# Altium schematic sheet P04_STM32MP1_PART_A_eMMC_.SchDoc(P04_STM32MP1_PART_A_eMMC_)
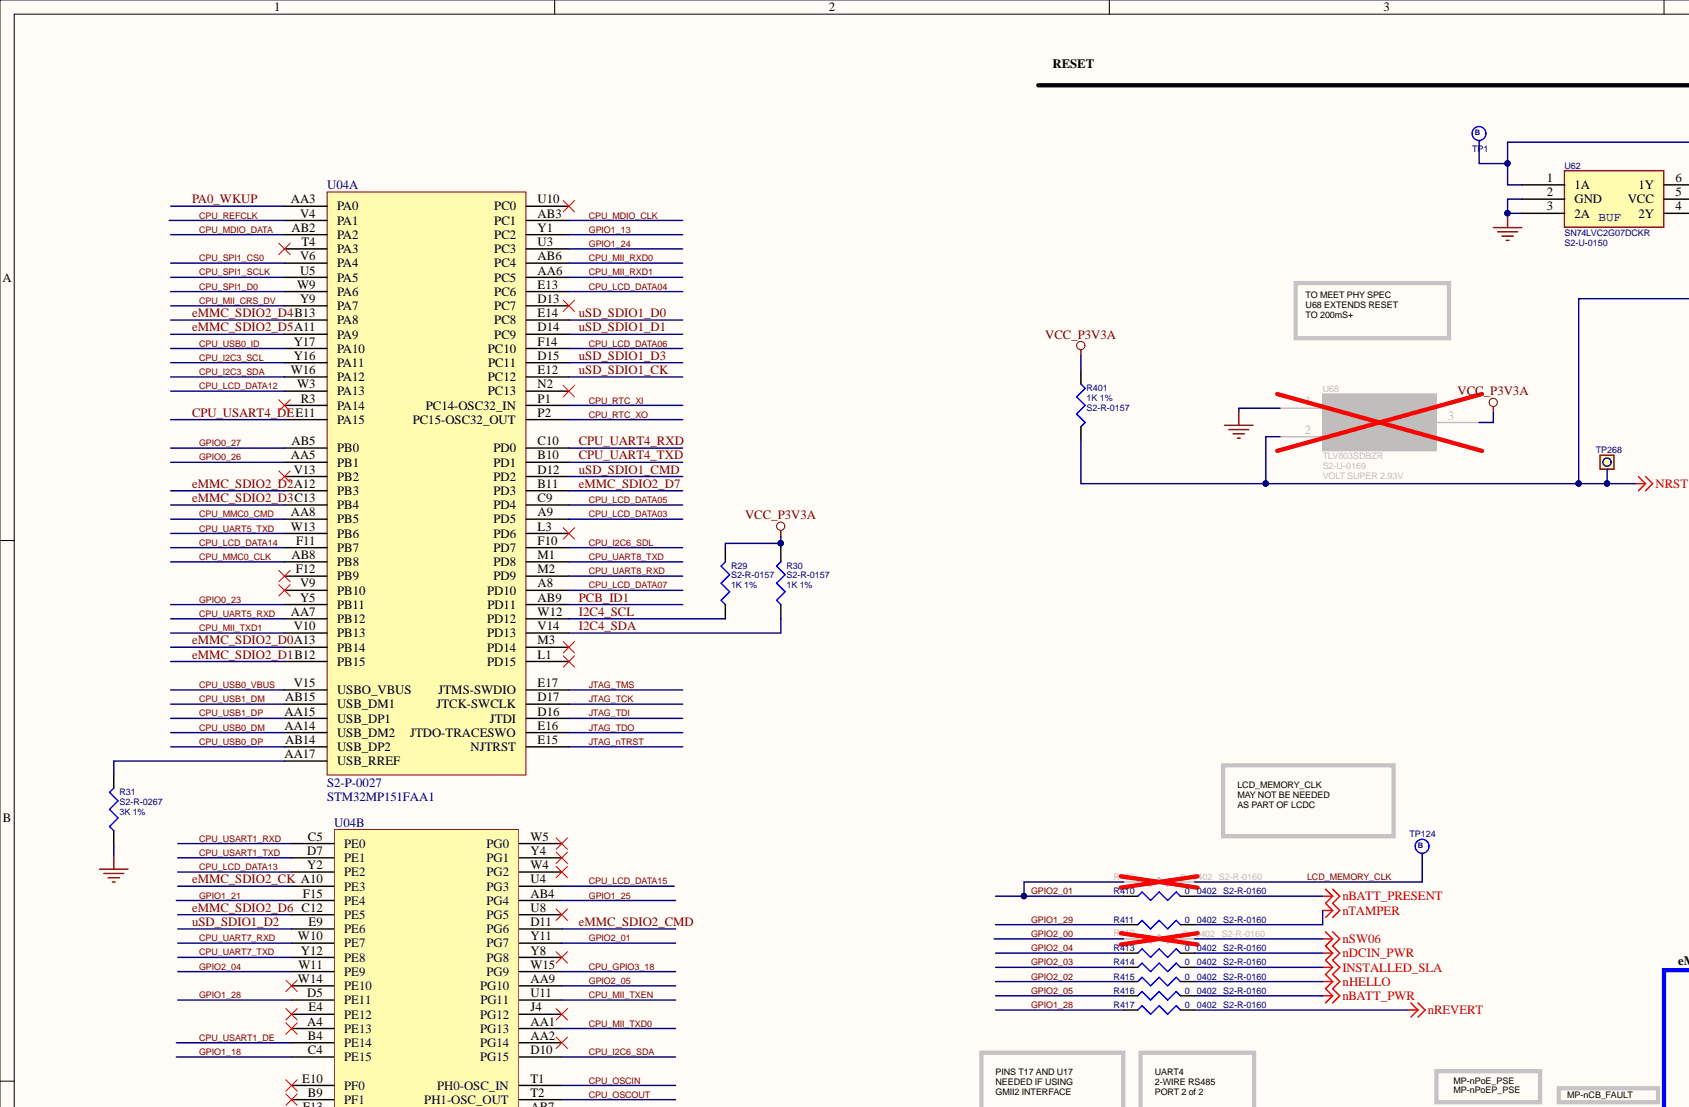
[diagram p0: P04_STM32MP1_PART_A_eMMC_.SchDoc, top left]
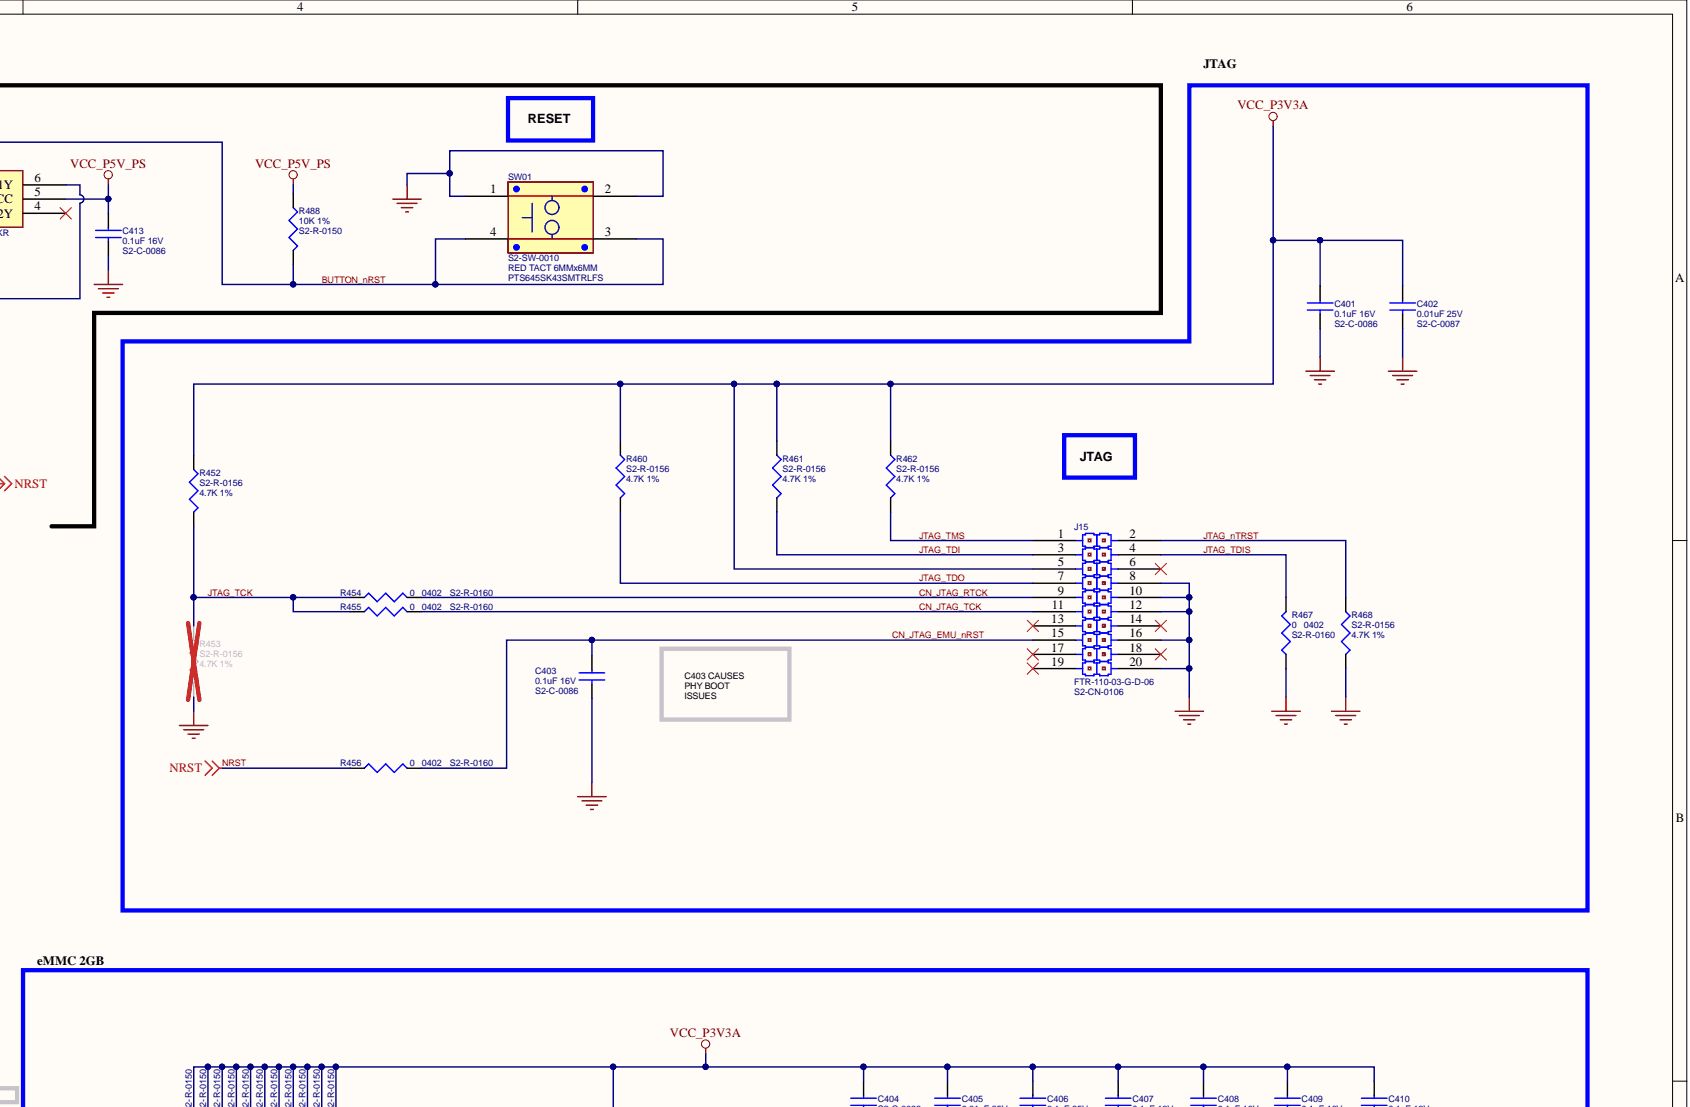
[diagram p1: P04_STM32MP1_PART_A_eMMC_.SchDoc, top right]
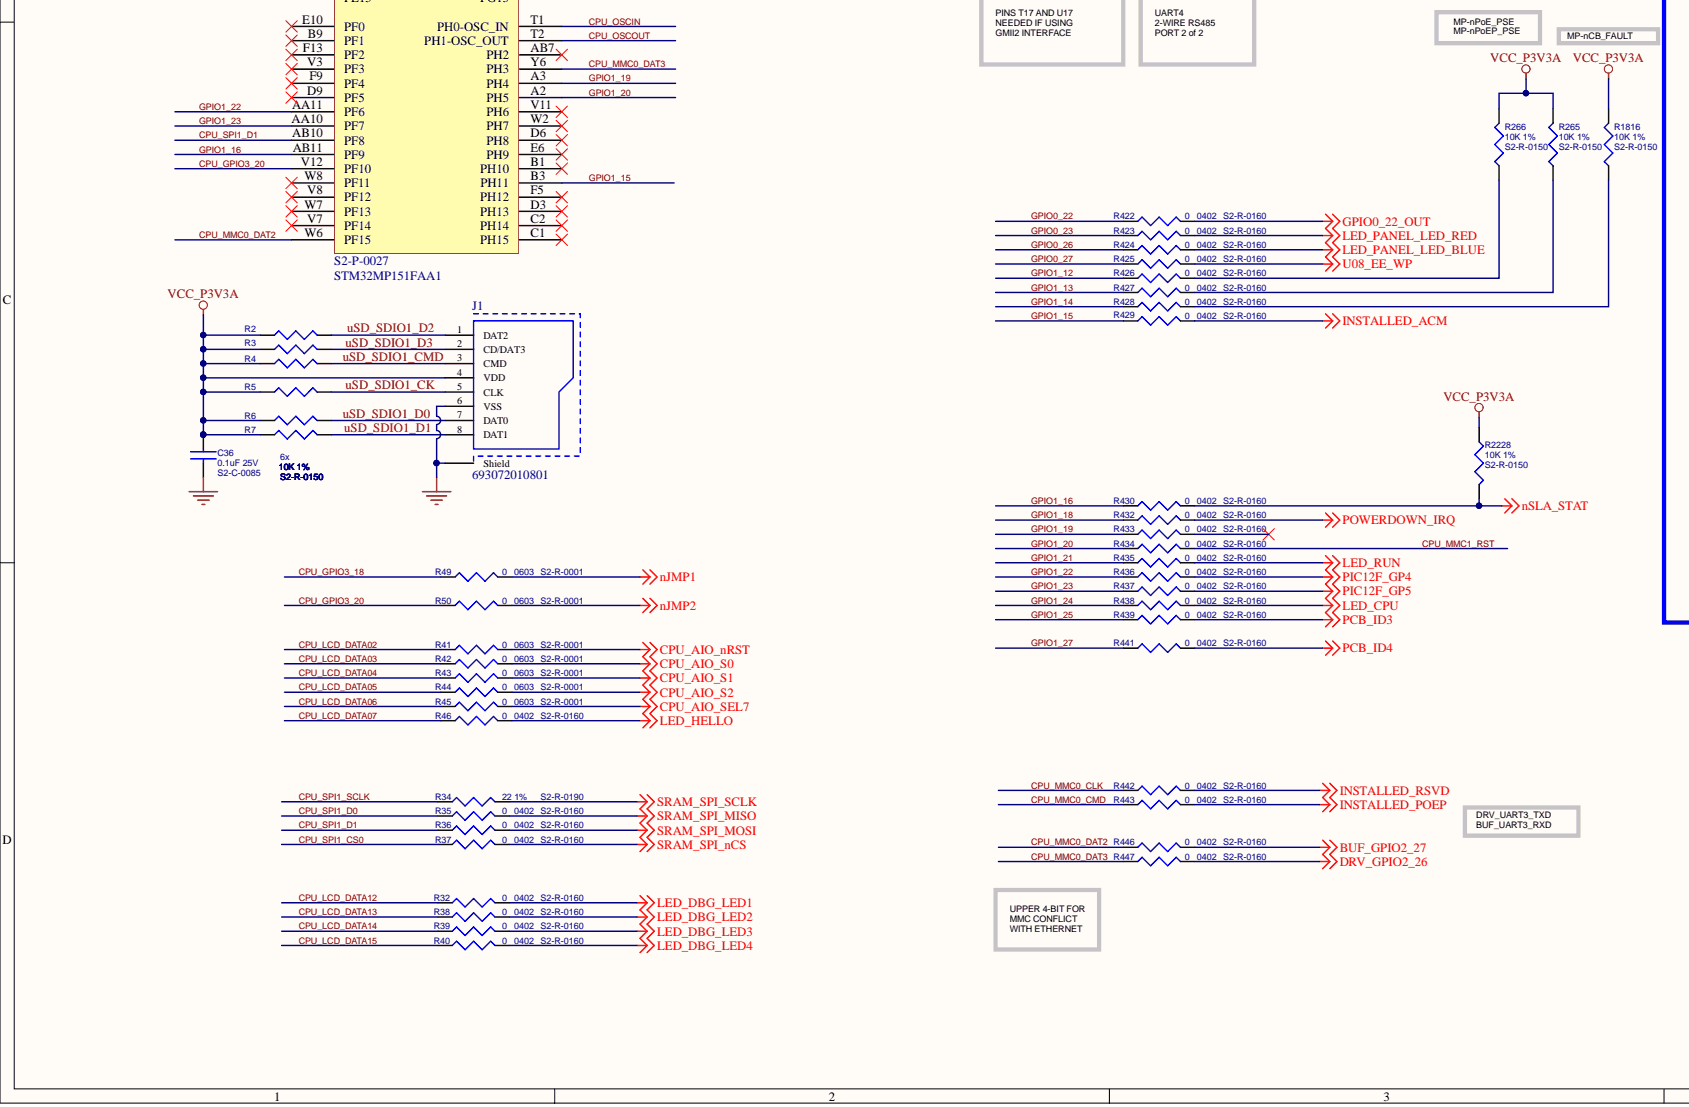
[diagram p2: P04_STM32MP1_PART_A_eMMC_.SchDoc, bottom left]
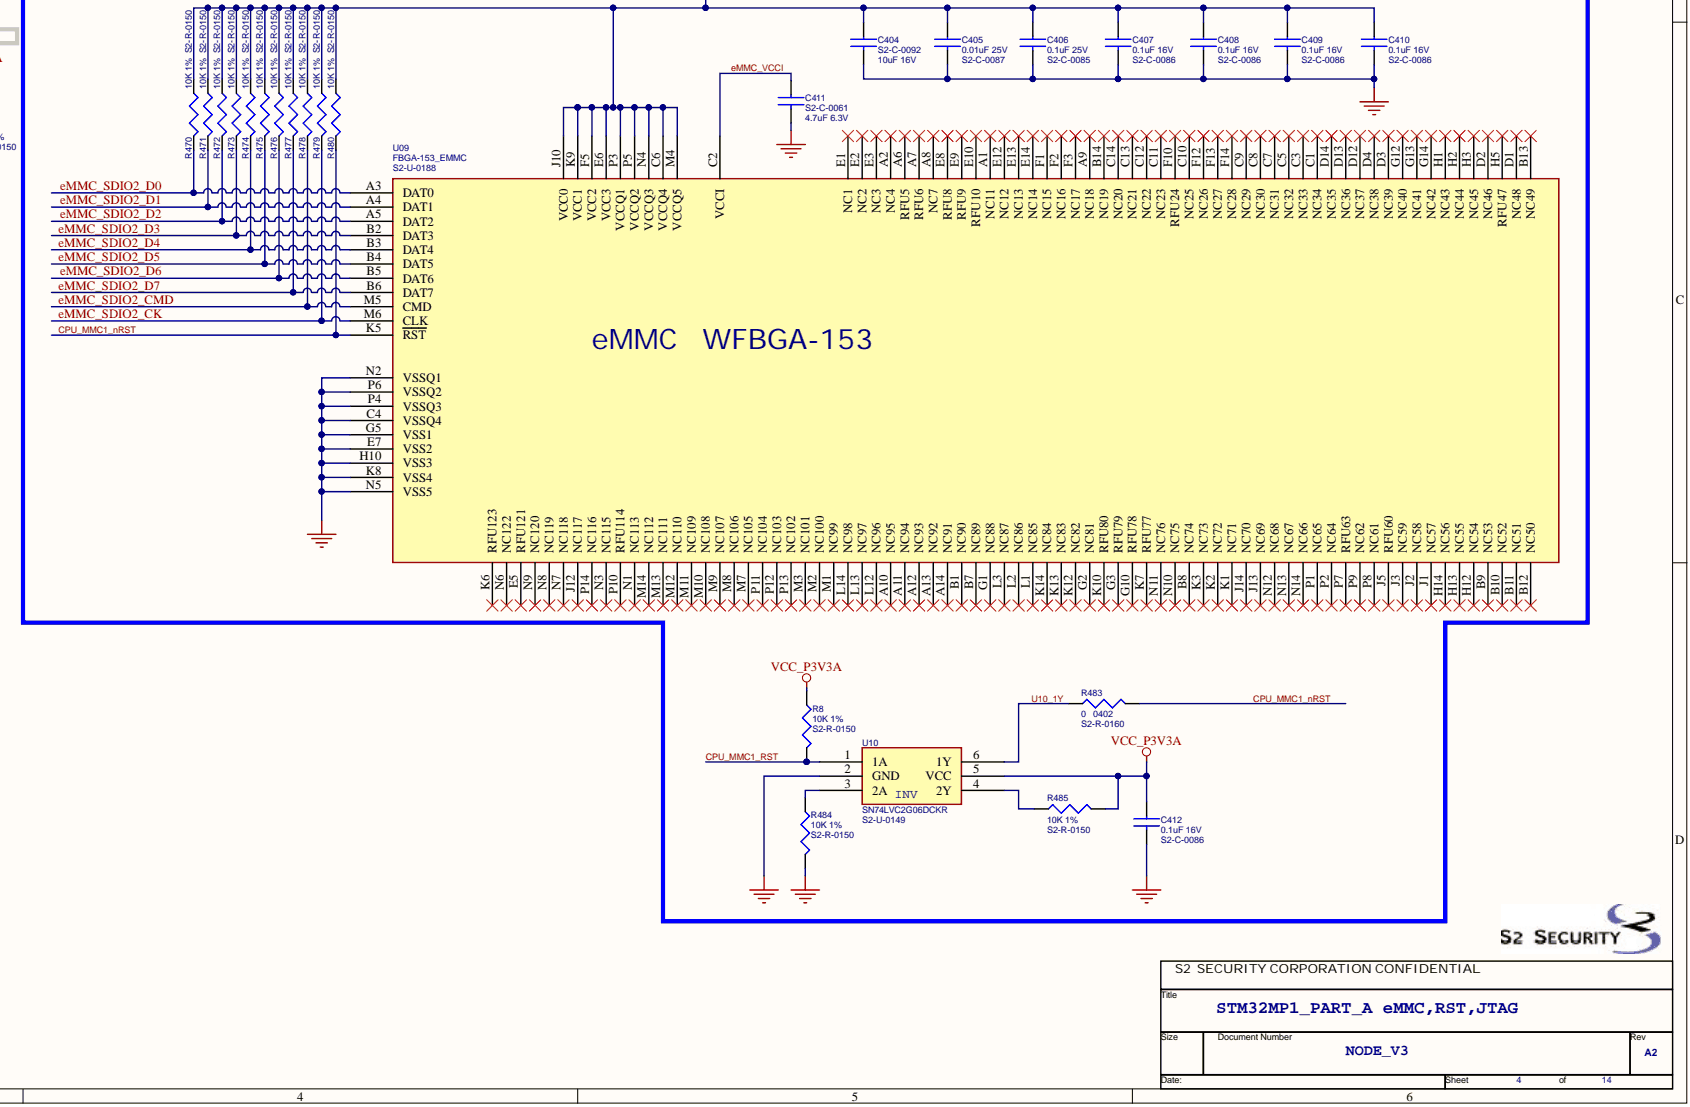
[diagram p3: P04_STM32MP1_PART_A_eMMC_.SchDoc, bottom right]

## Parts
C36  S2_PN: S2-C-0085  DESC: 0.1uF 25V  [CAPC1608X90N]  (hidden comment: C_0U1_25V_0603)
C401  S2_PN: S2-C-0086  DESC: 0.1uF 16V  [CAPC1005X55N]  (hidden comment: C_0U1_16V_0402)
C402  S2_PN: S2-C-0087  DESC: 0.01uF 25V  [CAPC1005X55N]  (hidden comment: C_0U01_25V_0402)
C403  S2_PN: S2-C-0086  DESC: 0.1uF 16V  [CAPC1005X55N]  (hidden comment: C_0U1_16V_0402)
C404  S2_PN: S2-C-0092  DESC: 10uF 16V  [CAPC2012X145N]  (hidden comment: C_10U_16V_0805)
C405  S2_PN: S2-C-0087  DESC: 0.01uF 25V  [CAPC1005X55N]  (hidden comment: C_0U01_25V_0402)
C406  S2_PN: S2-C-0085  DESC: 0.1uF 25V  [CAPC1608X90N]  (hidden comment: C_0U1_25V_0603)
C407  S2_PN: S2-C-0086  DESC: 0.1uF 16V  [CAPC1005X55N]  (hidden comment: C_0U1_16V_0402)
C408  S2_PN: S2-C-0086  DESC: 0.1uF 16V  [CAPC1005X55N]  (hidden comment: C_0U1_16V_0402)
C409  S2_PN: S2-C-0086  DESC: 0.1uF 16V  [CAPC1005X55N]  (hidden comment: C_0U1_16V_0402)
C410  S2_PN: S2-C-0086  DESC: 0.1uF 16V  [CAPC1005X55N]  (hidden comment: C_0U1_16V_0402)
C411  S2_PN: S2-C-0061  [CAPC1608X90N]  (hidden comment: C_4U7_6V3_0603)
C412  S2_PN: S2-C-0086  DESC: 0.1uF 16V  [CAPC1005X55N]  (hidden comment: C_0U1_16V_0402)
C413  S2_PN: S2-C-0086  DESC: 0.1uF 16V  [CAPC1005X55N]  (hidden comment: C_0U1_16V_0402)
J1  693072010801  [693072010801]
J15  S2_PN: S2-CN-0106  DESC: FTR-110-03-G-D-06  [CONN_FTR-110-03-G-D-06]  (hidden comment: CN_1MM27_20POS_10X2_UNSHRD_SMT)
R2  R_10K_0402  [RESC1005X40N]  (value not drawn)
R3  R_10K_0402  [RESC1005X40N]  (value not drawn)
R4  R_10K_0402  [RESC1005X40N]  (value not drawn)
R5  R_10K_0402  [RESC1005X40N]  (value not drawn)
R6  R_10K_0402  [RESC1005X40N]  (value not drawn)
R7  S2_PN: S2-R-0150  DESC: 10K 1%  [RESC1005X40N]  (hidden comment: R_10K_0402)
R8  S2_PN: S2-R-0150  DESC: 10K 1%  [RESC1005X40N]  (hidden comment: R_10K_0402)
R29  S2_PN: S2-R-0157  DESC: 1K 1%  [RESC1005X40N]  (hidden comment: R_1K_0402)
R30  S2_PN: S2-R-0157  DESC: 1K 1%  [RESC1005X40N]  (hidden comment: R_1K_0402)
R31  S2_PN: S2-R-0267  DESC: 3K 1%  [RESC1005X40N]  (hidden comment: R_3K_0402)
R32  S2_PN: S2-R-0160  DESC: 0   0402  [RESC1005X40N]  (hidden comment: R_0_0402)
R34  S2_PN: S2-R-0190  DESC: 22 1%  [RESC1608X55N]  (hidden comment: R_22_0603)
R35  S2_PN: S2-R-0160  DESC: 0   0402  [RESC1005X40N]  (hidden comment: R_0_0402)
R36  S2_PN: S2-R-0160  DESC: 0   0402  [RESC1005X40N]  (hidden comment: R_0_0402)
R37  S2_PN: S2-R-0160  DESC: 0   0402  [RESC1005X40N]  (hidden comment: R_0_0402)
R38  S2_PN: S2-R-0160  DESC: 0   0402  [RESC1005X40N]  (hidden comment: R_0_0402)
R39  S2_PN: S2-R-0160  DESC: 0   0402  [RESC1005X40N]  (hidden comment: R_0_0402)
R40  S2_PN: S2-R-0160  DESC: 0   0402  [RESC1005X40N]  (hidden comment: R_0_0402)
R41  S2_PN: S2-R-0001  DESC: 0   0603  [RESC1608X55N]  (hidden comment: R_0_0603)
R42  S2_PN: S2-R-0001  DESC: 0   0603  [RESC1608X55N]  (hidden comment: R_0_0603)
R43  S2_PN: S2-R-0001  DESC: 0   0603  [RESC1608X55N]  (hidden comment: R_0_0603)
R44  S2_PN: S2-R-0001  DESC: 0   0603  [RESC1608X55N]  (hidden comment: R_0_0603)
R45  S2_PN: S2-R-0001  DESC: 0   0603  [RESC1608X55N]  (hidden comment: R_0_0603)
R46  S2_PN: S2-R-0160  DESC: 0   0402  [RESC1005X40N]  (hidden comment: R_0_0402)
R49  S2_PN: S2-R-0001  DESC: 0   0603  [RESC1608X55N]  (hidden comment: R_0_0603)
R50  S2_PN: S2-R-0001  DESC: 0   0603  [RESC1608X55N]  (hidden comment: R_0_0603)
R265  S2_PN: S2-R-0150  DESC: 10K 1%  [RESC1005X40N]  (hidden comment: R_10K_0402)
R266  S2_PN: S2-R-0150  DESC: 10K 1%  [RESC1005X40N]  (hidden comment: R_10K_0402)
R401  S2_PN: S2-R-0157  DESC: 1K 1%  [RESC1005X40N]  (hidden comment: R_1K_0402)
R409  S2_PN: S2-R-0160  DESC: 0   0402  [RESC1005X40N]  (hidden comment: R_0_0402)
R410  S2_PN: S2-R-0160  DESC: 0   0402  [RESC1005X40N]  (hidden comment: R_0_0402)
R411  S2_PN: S2-R-0160  DESC: 0   0402  [RESC1005X40N]  (hidden comment: R_0_0402)
R412  S2_PN: S2-R-0160  DESC: 0   0402  [RESC1005X40N]  (hidden comment: R_0_0402)
R413  S2_PN: S2-R-0160  DESC: 0   0402  [RESC1005X40N]  (hidden comment: R_0_0402)
R414  S2_PN: S2-R-0160  DESC: 0   0402  [RESC1005X40N]  (hidden comment: R_0_0402)
R415  S2_PN: S2-R-0160  DESC: 0   0402  [RESC1005X40N]  (hidden comment: R_0_0402)
R416  S2_PN: S2-R-0160  DESC: 0   0402  [RESC1005X40N]  (hidden comment: R_0_0402)
R417  S2_PN: S2-R-0160  DESC: 0   0402  [RESC1005X40N]  (hidden comment: R_0_0402)
R422  S2_PN: S2-R-0160  DESC: 0   0402  [RESC1005X40N]  (hidden comment: R_0_0402)
R423  S2_PN: S2-R-0160  DESC: 0   0402  [RESC1005X40N]  (hidden comment: R_0_0402)
R424  S2_PN: S2-R-0160  DESC: 0   0402  [RESC1005X40N]  (hidden comment: R_0_0402)
R425  S2_PN: S2-R-0160  DESC: 0   0402  [RESC1005X40N]  (hidden comment: R_0_0402)
R426  S2_PN: S2-R-0160  DESC: 0   0402  [RESC1005X40N]  (hidden comment: R_0_0402)
R427  S2_PN: S2-R-0160  DESC: 0   0402  [RESC1005X40N]  (hidden comment: R_0_0402)
R428  S2_PN: S2-R-0160  DESC: 0   0402  [RESC1005X40N]  (hidden comment: R_0_0402)
R429  S2_PN: S2-R-0160  DESC: 0   0402  [RESC1005X40N]  (hidden comment: R_0_0402)
R430  S2_PN: S2-R-0160  DESC: 0   0402  [RESC1005X40N]  (hidden comment: R_0_0402)
R432  S2_PN: S2-R-0160  DESC: 0   0402  [RESC1005X40N]  (hidden comment: R_0_0402)
R433  S2_PN: S2-R-0160  DESC: 0   0402  [RESC1005X40N]  (hidden comment: R_0_0402)
R434  S2_PN: S2-R-0160  DESC: 0   0402  [RESC1005X40N]  (hidden comment: R_0_0402)
R435  S2_PN: S2-R-0160  DESC: 0   0402  [RESC1005X40N]  (hidden comment: R_0_0402)
R436  S2_PN: S2-R-0160  DESC: 0   0402  [RESC1005X40N]  (hidden comment: R_0_0402)
R437  S2_PN: S2-R-0160  DESC: 0   0402  [RESC1005X40N]  (hidden comment: R_0_0402)
R438  S2_PN: S2-R-0160  DESC: 0   0402  [RESC1005X40N]  (hidden comment: R_0_0402)
R439  S2_PN: S2-R-0160  DESC: 0   0402  [RESC1005X40N]  (hidden comment: R_0_0402)
R441  S2_PN: S2-R-0160  DESC: 0   0402  [RESC1005X40N]  (hidden comment: R_0_0402)
R442  S2_PN: S2-R-0160  DESC: 0   0402  [RESC1005X40N]  (hidden comment: R_0_0402)
R443  S2_PN: S2-R-0160  DESC: 0   0402  [RESC1005X40N]  (hidden comment: R_0_0402)
R446  S2_PN: S2-R-0160  DESC: 0   0402  [RESC1005X40N]  (hidden comment: R_0_0402)
R447  S2_PN: S2-R-0160  DESC: 0   0402  [RESC1005X40N]  (hidden comment: R_0_0402)
R452  S2_PN: S2-R-0156  DESC: 4.7K 1%  [RESC1005X40N]  (hidden comment: R_4K7_0402)
R453  S2_PN: S2-R-0156  DESC: 4.7K 1%  [RESC1005X40N]  (hidden comment: R_4K7_0402)
R454  S2_PN: S2-R-0160  DESC: 0   0402  [RESC1005X40N]  (hidden comment: R_0_0402)
R455  S2_PN: S2-R-0160  DESC: 0   0402  [RESC1005X40N]  (hidden comment: R_0_0402)
R456  S2_PN: S2-R-0160  DESC: 0   0402  [RESC1005X40N]  (hidden comment: R_0_0402)
R460  S2_PN: S2-R-0156  DESC: 4.7K 1%  [RESC1005X40N]  (hidden comment: R_4K7_0402)
R461  S2_PN: S2-R-0156  DESC: 4.7K 1%  [RESC1005X40N]  (hidden comment: R_4K7_0402)
R462  S2_PN: S2-R-0156  DESC: 4.7K 1%  [RESC1005X40N]  (hidden comment: R_4K7_0402)
R467  S2_PN: S2-R-0160  DESC: 0   0402  [RESC1005X40N]  (hidden comment: R_0_0402)
R468  S2_PN: S2-R-0156  DESC: 4.7K 1%  [RESC1005X40N]  (hidden comment: R_4K7_0402)
R470  S2_PN: S2-R-0150  DESC: 10K 1%  [RESC1005X40N]  (hidden comment: R_10K_0402)
R471  S2_PN: S2-R-0150  DESC: 10K 1%  [RESC1005X40N]  (hidden comment: R_10K_0402)
R472  S2_PN: S2-R-0150  DESC: 10K 1%  [RESC1005X40N]  (hidden comment: R_10K_0402)
R473  S2_PN: S2-R-0150  DESC: 10K 1%  [RESC1005X40N]  (hidden comment: R_10K_0402)
R474  S2_PN: S2-R-0150  DESC: 10K 1%  [RESC1005X40N]  (hidden comment: R_10K_0402)
R475  S2_PN: S2-R-0150  DESC: 10K 1%  [RESC1005X40N]  (hidden comment: R_10K_0402)
R476  S2_PN: S2-R-0150  DESC: 10K 1%  [RESC1005X40N]  (hidden comment: R_10K_0402)
R477  S2_PN: S2-R-0150  DESC: 10K 1%  [RESC1005X40N]  (hidden comment: R_10K_0402)
R478  S2_PN: S2-R-0150  DESC: 10K 1%  [RESC1005X40N]  (hidden comment: R_10K_0402)
R479  S2_PN: S2-R-0150  DESC: 10K 1%  [RESC1005X40N]  (hidden comment: R_10K_0402)
R480  S2_PN: S2-R-0150  DESC: 10K 1%  [RESC1005X40N]  (hidden comment: R_10K_0402)
R483  S2_PN: S2-R-0160  DESC: 0   0402  [RESC1005X40N]  (hidden comment: R_0_0402)
R484  S2_PN: S2-R-0150  DESC: 10K 1%  [RESC1005X40N]  (hidden comment: R_10K_0402)
R485  S2_PN: S2-R-0150  DESC: 10K 1%  [RESC1005X40N]  (hidden comment: R_10K_0402)
R488  S2_PN: S2-R-0150  DESC: 10K 1%  [RESC1005X40N]  (hidden comment: R_10K_0402)
R1816  S2_PN: S2-R-0150  DESC: 10K 1%  [RESC1005X40N]  (hidden comment: R_10K_0402)
R2228  S2_PN: S2-R-0150  DESC: 10K 1%  [RESC1005X40N]  (hidden comment: R_10K_0402)
SW01  S2_PN: S2-SW-0010  DESC: PTS645SK43SMTRLFS  ATTR: RED TACT 6MMx6MM  [SW_PTS645SK43SMTRLFS]  (hidden comment: SW_PTS645_SMT_RED)
TP1  TP_SMT_TEST_POINT_BOTTOM  [TP35_SMT]  (value not drawn)
TP124  TP_SMT_TEST_POINT_BOTTOM  [TP35_SMT]  (value not drawn)
TP268  TP_TH_TEST_POINT_SQUARE  [TEST-PT-TH-SQ]  (value not drawn)
U04  S2_PN: S2-P-0027  DESC: STM32MP151FAA1  [ICC_LFBGA448_18X18_STM32MP157BAA3_P080]  (hidden comment: U_STM32MP15xxAAx)  (parts A,B)
U09  S2_PN: S2-U-0188  DESC: FBGA-153_EMMC  [WFBGA153$5C$11P5X13$5C$P5MM]  (hidden comment: U_EMMC_WFBGA153)
U10  S2_PN: S2-U-0149  DESC: SN74LVC2G06DCKR  [SOIC65Px210X110P-6N]  (hidden comment: U_SN74LVC2G06DCKR_DUAL_INVERTER)
U62  S2_PN: S2-U-0150  DESC: SN74LVC2G07DCKR  [SOIC65Px210X110P-6N]  (hidden comment: U_SN74LVC2G07DCKR_DUAL_BUFFER)
U68  S2_PN: S2-U-0169  DESC: TLV803SDBZR  ATTR2: VOLT SUPER 2.93V  [SOT95P310X140-3N]  (hidden comment: U_VOLT_SUPER_2V93_SOT23)

## Nets
BUF_GPIO2_27: R446.2
CPU_AIO_nRST: R41.2
CPU_AIO_S0: R42.2
CPU_AIO_S1: R43.2
CPU_AIO_S2: R44.2
CPU_AIO_SEL7: R45.2
DRV_GPIO2_26: R447.2
GND: C36.2 C401.2 C402.2 C403.2 C404.2 C405.2 C406.2 C407.2 C408.2 C409.2 C410.2 C411.2 C412.2 C413.2 J1.6(VSS) J1.Shield J15.8 J15.10 J15.12 J15.16 J15.20 R31.1 R453.2 R467.2 R468.2 R484.2 SW01.1 SW01.2 U09.C4(VSSQ4) U09.E7(VSS2) U09.G5(VSS1) U09.H10(VSS3) U09.K8(VSS4) U09.N2(VSSQ1) U09.N5(VSS5) U09.P4(VSSQ3) U09.P6(VSSQ2) U10.2(GND) U62.2(GND) U62.3(2A) U68.1
GPIO0_22_OUT: R422.2
INSTALLED_ACM: R429.2
INSTALLED_POEP: R443.2
INSTALLED_RSVD: R442.2
INSTALLED_SLA: R414.2
LED_CPU: R438.2
LED_DBG_LED1: R32.2
LED_DBG_LED2: R38.2
LED_DBG_LED3: R39.2
LED_DBG_LED4: R40.2
LED_HELLO: R46.2
LED_PANEL_LED_BLUE: R424.2
LED_PANEL_LED_RED: R423.2
LED_RUN: R435.2
nBATT_PRESENT: R410.2
nBATT_PWR: R416.2
nDCIN_PWR: R413.2
nHELLO: R415.2
nJMP1: R49.2
nJMP2: R50.2
nREVERT: R417.2
nSLA_STAT: R430.2 R2228.2
nSW06: R412.2
nTAMPER: R411.2
PCB_ID3: R439.2
PCB_ID4: R441.2
PIC12F_GP4: R436.2
PIC12F_GP5: R437.2
POWERDOWN_IRQ: R432.2
SRAM_SPI_MISO: R35.2
SRAM_SPI_MOSI: R36.2
SRAM_SPI_nCS: R37.2
SRAM_SPI_SCLK: R34.2
U08_EE_WP: R425.2
VCC_P3V3A: C36.1 C401.1 C402.1 C404.1 C405.1 C406.1 C407.1 C408.1 C409.1 C410.1 C412.1 J1.4(VDD) J15.5 R2.1 R3.1 R4.1 R5.1 R6.1 R7.1 R8.1 R29.2 R30.2 R265.1 R266.1 R401.1 R452.1 R460.1 R461.1 R462.1 R470.1 R471.1 R472.1 R473.1 R474.1 R475.1 R476.1 R477.1 R478.1 R479.1 R480.1 R485.2 R1816.1 R2228.1 U09.C6(VCCQ4) U09.E6(VCC3) U09.F5(VCC2) U09.J10(VCC0) U09.K9(VCC1) U09.M4(VCCQ5) U09.N4(VCCQ3) U09.P3(VCCQ1) U09.P5(VCCQ2) U10.5(VCC) U68.3
VCC_P5V_PS: C413.1 R488.1 U62.5(VCC)
BUTTON_nRST: R488.2 SW01.3 SW01.4 TP1.1 U62.1(1A)
CN_JTAG_EMU_nRST: C403.1 J15.15 R456.2
CN_JTAG_RTCK: J15.9 R454.2
CN_JTAG_TCK: J15.11 R455.2
CPU_GPIO3_18: R49.1 U04.W15(PG9)
CPU_GPIO3_20: R50.1 U04.V12(PF10)
CPU_I2C3_SCL: U04.Y16(PA11)
CPU_I2C3_SDA: U04.W16(PA12)
CPU_I2C6_SDA: U04.D10(PG15)
CPU_I2C6_SDL: U04.F10(PD7)
CPU_LCD_DATA02: R41.1
CPU_LCD_DATA03: R42.1 U04.A9(PD5)
CPU_LCD_DATA04: R43.1 U04.E13(PC6)
CPU_LCD_DATA05: R44.1 U04.C9(PD4)
CPU_LCD_DATA06: R45.1 U04.F14(PC10)
CPU_LCD_DATA07: R46.1 U04.A8(PD10)
CPU_LCD_DATA12: R32.1 U04.W3(PA13)
CPU_LCD_DATA13: R38.1 U04.Y2(PE2)
CPU_LCD_DATA14: R39.1 U04.F11(PB7)
CPU_LCD_DATA15: R40.1 U04.U4(PG3)
CPU_MDIO_CLK: U04.AB3(PC1)
CPU_MDIO_DATA: U04.AB2(PA2)
CPU_MII_CRS_DV: U04.Y9(PA7)
CPU_MII_RXD0: U04.AB6(PC4)
CPU_MII_RXD1: U04.AA6(PC5)
CPU_MII_TXD0: U04.AA1(PG13)
CPU_MII_TXD1: U04.V10(PB13)
CPU_MII_TXEN: U04.U11(PG11)
CPU_MMC0_CLK: R442.1 U04.AB8(PB8)
CPU_MMC0_CMD: R443.1 U04.AA8(PB5)
CPU_MMC0_DAT2: R446.1 U04.W6(PF15)
CPU_MMC0_DAT3: R447.1 U04.Y6(PH3)
CPU_MMC1_nRST: R480.2 R483.2 U09.K5(RST)
CPU_MMC1_RST: R8.2 R434.2 U10.1(1A)
CPU_OSCIN: U04.T1(PH0-OSC_IN)
CPU_OSCOUT: U04.T2(PH1-OSC_OUT)
CPU_REFCLK: U04.V4(PA1)
CPU_RTC_XI: U04.P1(PC14-OSC32_IN)
CPU_RTC_XO: U04.P2(PC15-OSC32_OUT)
CPU_SPI1_CS0: R37.1 U04.V6(PA4)
CPU_SPI1_D0: R35.1 U04.W9(PA6)
CPU_SPI1_D1: R36.1 U04.AB10(PF8)
CPU_SPI1_SCLK: R34.1 U04.U5(PA5)
CPU_UART4_RXD: U04.C10(PD0)
CPU_UART4_TXD: U04.B10(PD1)
CPU_UART5_RXD: U04.AA7(PB12)
CPU_UART5_TXD: U04.W13(PB6)
CPU_UART7_RXD: U04.W10(PE7)
CPU_UART7_TXD: U04.Y12(PE8)
CPU_UART8_RXD: U04.M2(PD9)
CPU_UART8_TXD: U04.M1(PD8)
CPU_USART1_DE: U04.B4(PE14)
CPU_USART1_RXD: U04.C5(PE0)
CPU_USART1_TXD: U04.D7(PE1)
CPU_USART4_DE: U04.E11(PA15)
CPU_USB0_DM: U04.AA14(USB_DM2)
CPU_USB0_DP: U04.AB14(USB_DP2)
CPU_USB0_ID: U04.Y17(PA10)
CPU_USB0_VBUS: U04.V15(USBO_VBUS)
CPU_USB1_DM: U04.AB15(USB_DM1)
CPU_USB1_DP: U04.AA15(USB_DP1)
eMMC_SDIO2_CK: R479.2 U04.A10(PE3) U09.M6(CLK)
eMMC_SDIO2_CMD: R478.2 U04.D11(PG6) U09.M5(CMD)
eMMC_SDIO2_D0: R470.2 U04.A13(PB14) U09.A3(DAT0)
eMMC_SDIO2_D1: R471.2 U04.B12(PB15) U09.A4(DAT1)
eMMC_SDIO2_D2: R472.2 U04.A12(PB3) U09.A5(DAT2)
eMMC_SDIO2_D3: R473.2 U04.C13(PB4) U09.B2(DAT3)
eMMC_SDIO2_D4: R474.2 U04.B13(PA8) U09.B3(DAT4)
eMMC_SDIO2_D5: R475.2 U04.A11(PA9) U09.B4(DAT5)
eMMC_SDIO2_D6: R476.2 U04.C12(PE5) U09.B5(DAT6)
eMMC_SDIO2_D7: R477.2 U04.B11(PD3) U09.B6(DAT7)
eMMC_VCCI: C411.1 U09.C2(VCCI)
GPIO0_22: R422.1
GPIO0_23: R423.1 U04.Y5(PB11)
GPIO0_26: R424.1 U04.AA5(PB1)
GPIO0_27: R425.1 U04.AB5(PB0)
GPIO1_12: R426.1
GPIO1_13: R427.1 U04.Y1(PC2)
GPIO1_14: R428.1
GPIO1_15: R429.1 U04.B3(PH11)
GPIO1_16: R430.1 U04.AB11(PF9)
GPIO1_18: R432.1 U04.C4(PE15)
GPIO1_19: R433.1 U04.A3(PH4)
GPIO1_20: R434.1 U04.A2(PH5)
GPIO1_21: R435.1 U04.F15(PE4)
GPIO1_22: R436.1 U04.AA11(PF6)
GPIO1_23: R437.1 U04.AA10(PF7)
GPIO1_24: R438.1 U04.U3(PC3)
GPIO1_25: R439.1 U04.AB4(PG4)
GPIO1_27: R441.1
GPIO1_28: R417.1 U04.D5(PE11)
GPIO1_29: R411.1
GPIO2_00: R412.1
GPIO2_01: R409.1 R410.1 U04.Y11(PG7)
GPIO2_02: R415.1
GPIO2_03: R414.1
GPIO2_04: R413.1 U04.W11(PE9)
GPIO2_05: R416.1 U04.AA9(PG10)
I2C4_SCL: R29.1 U04.W12(PD12)
I2C4_SDA: R30.1 U04.V14(PD13)
JTAG_nTRST: J15.2 R468.1 U04.E15(NJTRST)
JTAG_TCK: R452.2 R453.1 R454.1 R455.1 U04.D17(JTCK-SWCLK)
JTAG_TDI: J15.3 R461.2 U04.D16(JTDI)
JTAG_TDIS: J15.4 R467.1
JTAG_TDO: J15.7 R460.2 U04.E16(JTDO-TRACESWO)
JTAG_TMS: J15.1 R462.2 U04.E17(JTMS-SWDIO)
LCD_MEMORY_CLK: R409.2 TP124.1
NRST: R401.2 R456.1 TP268.1 U62.6(1Y) U68.2
PA0_WKUP: U04.AA3(PA0)
PCB_ID1: U04.AB9(PD11)
U10_1Y: R483.1 U10.6(1Y)
uSD_SDIO1_CK: J1.5(CLK) R5.2 U04.E12(PC12)
uSD_SDIO1_CMD: J1.3(CMD) R4.2 U04.D12(PD2)
uSD_SDIO1_D0: J1.7(DAT0) R6.2 U04.E14(PC8)
uSD_SDIO1_D1: J1.8(DAT1) R7.2 U04.D14(PC9)
uSD_SDIO1_D2: J1.1(DAT2) R2.2 U04.E9(PE6)
uSD_SDIO1_D3: J1.2(CD/DAT3) R3.2 U04.D15(PC11)
NetR31_2: R31.2 U04.AA17(USB_RREF)
NetR265_2: R265.2 R427.2
NetR266_2: R266.2 R426.2
NetR428_2: R428.2 R1816.2
NetR484_1: R484.1 U10.3(2A)
NetR485_1: R485.1 U10.4(2Y)

Not connected: J15 6 13 14 17 18 19; R433 2; U04 A4(PE13) AA2(PG14) AB7(PH2) B1(PH10) B9(PF1) C1(PH15) C2(PH14) D3(PH13) D6(PH8) D9(PF5) D13(PC7) E4(PE12) E6(PH9) E10(PF0) F5(PH12) F9(PF4) F12(PB9) F13(PF2) J4(PG12) L1(PD15) L3(PD6) M3(PD14) N2(PC13) R3(PA14) T4(PA3) U8(PG5) U10(PC0) V3(PF3) V7(PF14) V8(PF12) V9(PB10) V11(PH6) V13(PB2) W2(PH7) W4(PG2) W5(PG0) W7(PF13) W8(PF11) W14(PE10) Y4(PG1) Y8(PG8); U09 A1(NC11) A2(NC4) A6(RFU5) A7(RFU6) A8(NC7) A9(NC18) A10(NC95) A11(NC94) A12(NC93) A13(NC92) A14(NC91) B1(NC90) B7(NC89) B8(NC74) B9(NC53) B10(NC52) B11(NC51) B12(NC50) B13(NC49) B14(NC19) C1(NC34) C3(NC33) C5(NC32) C7(NC31) C8(NC30) C9(NC29) C10(NC25) C11(NC23) C12(NC22) C13(NC21) C14(NC20) D1(NC48) D2(NC46) D3(NC39) D4(NC38) D12(NC37) D13(NC36) D14(NC35) E1(NC1) E2(NC2) E3(NC3) E5(RFU121) E8(RFU8) E9(RFU9) E10(RFU10) E12(NC12) E13(NC13) E14(NC14) F1(NC15) F2(NC16) F3(NC17) F10(RFU24) F12(NC26) F13(NC27) F14(NC28) G1(NC88) G2(NC81) G3(RFU79) G10(RFU78) G12(NC40) G13(NC41) G14(NC42) H1(NC43) H2(NC44) H3(NC45) H5(RFU47) H12(NC54) H13(NC55) H14(NC56) J1(NC57) J2(NC58) J3(NC59) J5(RFU60) J12(NC117) J13(NC69) J14(NC70) K1(NC71) K2(NC72) K3(NC73) K6(RFU123) K7(RFU77) K10(RFU80) K12(NC82) K13(NC83) K14(NC84) L1(NC85) L2(NC86) L3(NC87) L12(NC96) L13(NC97) L14(NC98) M1(NC99) M2(NC100) M3(NC101) M7(NC105) M8(NC106) M9(NC107) M10(NC108) M11(NC109) M12(NC110) M13(NC111) M14(NC112) N1(NC113) N3(NC115) N6(NC122) N7(NC118) N8(NC119) N9(NC120) N10(NC75) N11(NC76) N12(NC68) N13(NC67) N14(NC66) P1(NC65) P2(NC64) P7(RFU63) P8(NC61) P9(NC62) P10(RFU114) P11(NC104) P12(NC103) P13(NC102) P14(NC116); U62 4(2Y)

## Library data of the parts
C36 C406 = C_0U1_25V_0603: PKG: CC0603IPC; ATTR: X7R 10%; Library Name: NODE_V2_M1.SCHLIB; Component Kind: Standard
C401 C403 C407-C410 C412 C413 = C_0U1_16V_0402: PKG: CC0402IPC; ATTR: X7R 10%; Library Name: NODE_V2_M1.SCHLIB; Component Kind: Standard
C402 C405 = C_0U01_25V_0402: PKG: CC0402IPC; ATTR: X7R 10%; Library Name: NODE_V2_M1.SCHLIB; Component Kind: Standard
C404 = C_10U_16V_0805: PKG: CC0805IPC; ATTR: X5R 10%; Library Name: NODE_V2_M1.SCHLIB; Component Kind: Standard
C411 = C_4U7_6V3_0603: PKG: CC0603IPC; DESC: 4.7uF 6.3V; ATTR: X5R 10%; Library Name: NODE_V2_M1.SCHLIB; Component Kind: Standard
J1 = 693072010801: WR-CRD Micro SD Card Connector Hinge Type; Working Voltage: 100VAC; Withstanding Voltage: 500VAC; Rated Current: 0.5A; Pin Number: 8; Gender: Socket; Contact Resistance: 100mOhm; Type: Hinge; Published Date: November 2019; Part Number: 693072010801; Packaging: Tape and Reel; Operating Temperature: -25 to +85degC; Mounting Technology: SMT; Library Name: 693022010811_Altium_WR-CRD_rev19a.IntLib; ComponentLink2URL: https://katalog.we-online.com/em/datasheet/693072010801.pdf; ComponentLink2Description: Datasheet; ComponentLink1URL: http://www.we-online.de; ComponentLink1Description: Manufacturer; Component Kind: Standard; Publisher: Wurth Elektronik
J15 = CN_1MM27_20POS_10X2_UNSHRD_SMT: PKG: FTR_10x2_SMT; ATTR: HEADER 20POS 1.27MM DUAL SMD; Library Name: NODE_V2_M1.SCHLIB; Component Kind: Standard
R2-R6 = R_10K_0402: S2_PN: S2-R-0150; PKG: CC0402IPC; DESC: 10K 1%; ATTR: 1/16W; Library Name: NODE_V2_M1.SCHLIB; Component Kind: Standard
R7 R8 R265 R266 R470-R480 R484 R485 R488 R1816 R2228 = R_10K_0402: PKG: CC0402IPC; ATTR: 1/16W; Library Name: NODE_V2_M1.SCHLIB; Component Kind: Standard
R29 R30 R401 = R_1K_0402: PKG: CC0402IPC; ATTR: 1/16W; Library Name: NODE_V2_M1.SCHLIB; Component Kind: Standard
R31 = R_3K_0402: PKG: CC0402IPC; ATTR: 1/16W; Library Name: NODE_V2_M1.SCHLIB; DNP: DNP; Component Kind: Standard
R32 R35-R40 R46 R409-R417 R422-R430 R432-R439 R441-R443 R446 R447 R454-R456 R467 R483 = R_0_0402: PKG: CC0402IPC; ATTR: 1/16W  50mohm MAX; Library Name: NODE_V2_M1.SCHLIB; Component Kind: Standard
R34 = R_22_0603: PKG: CC0603IPC; ATTR: RES 22 OHM 1/10W 1% 0603; Library Name: NODE_V2_M1.SCHLIB; Component Kind: Standard
R41-R45 R49 R50 = R_0_0603: PKG: CC0603IPC; ATTR: 1/10W 50mohm MAX; Library Name: NODE_V2_M1.SCHLIB; Component Kind: Standard
R452 R453 R460-R462 R468 = R_4K7_0402: PKG: CC0402IPC; ATTR: 1/16W; Library Name: NODE_V2_M1.SCHLIB; Component Kind: Standard
SW01 = SW_PTS645_SMT_RED: ATTR2: SWITCH SMT RED 6MMx6MM; PKG: PTS645_SMT; Library Name: NODE_V2_M1.SCHLIB; Component Kind: Standard
TP1 TP124 = TP_SMT_TEST_POINT_BOTTOM: S2_PN: S2-TP-0003; PKG: TEST-PT-TPB; DESC: TEST POINT; ATTR: SMT BOTTOM SIDE TEST POINT; Library Name: NODE_V2_M1.SCHLIB; DNP: DNP; Component Kind: Standard
TP268 = TP_TH_TEST_POINT_SQUARE: S2_PN: S2-TP-0004; PKG: TEST-PT-TH; DESC: TEST POINT; ATTR: THRU HOLE TEST POINT; Library Name: NODE_V2_M1.SCHLIB; DNP: DNP; Component Kind: Standard
U04 = (no symbol name): MPU LFBGA18x18; ATTR: ARM Cortex-A7 Microprocessor IC STM32MP1 1 Core, 32-Bit 209MHz, 800MHz 448-LFBGA (18x18); PKG: LFBGA18x18; Library Name: NODE_V2_M1.SCHLIB; Component Kind: Standard
U09 = U_EMMC_WFBGA153: PKG: WFBGA-153_EMMC; ATTR: MEMORY EMMC 153-PIN FBGA; Library Name: NODE_V2_M1.SCHLIB; Component Kind: Standard
U10 = U_SN74LVC2G06DCKR_DUAL_INVERTER: PKG: SOT-363; ATTR: IC 74LVC2G06 INVERTER DUAL OPEN DRAIN 1.65V-5.5V OPERATION; Library Name: NODE_V2_M1.SCHLIB; Component Kind: Standard
U62 = U_SN74LVC2G07DCKR_DUAL_BUFFER: PKG: SOT-363; ATTR: IC 74LVC2G07 BUFFER DUAL OPEN DRAIN 1.65V-5.5V OPERATION; Library Name: NODE_V2_M1.SCHLIB; Component Kind: Standard
U68 = U_VOLT_SUPER_2V93_SOT23: PKG: SOT-23-3; ATTR: IC VOLT SUPERVISOR 2.93V SOT23-3; Library Name: NODE_V2_M1.SCHLIB; Component Kind: Standard
